# Altium schematic sheet 四路运放.SchDoc
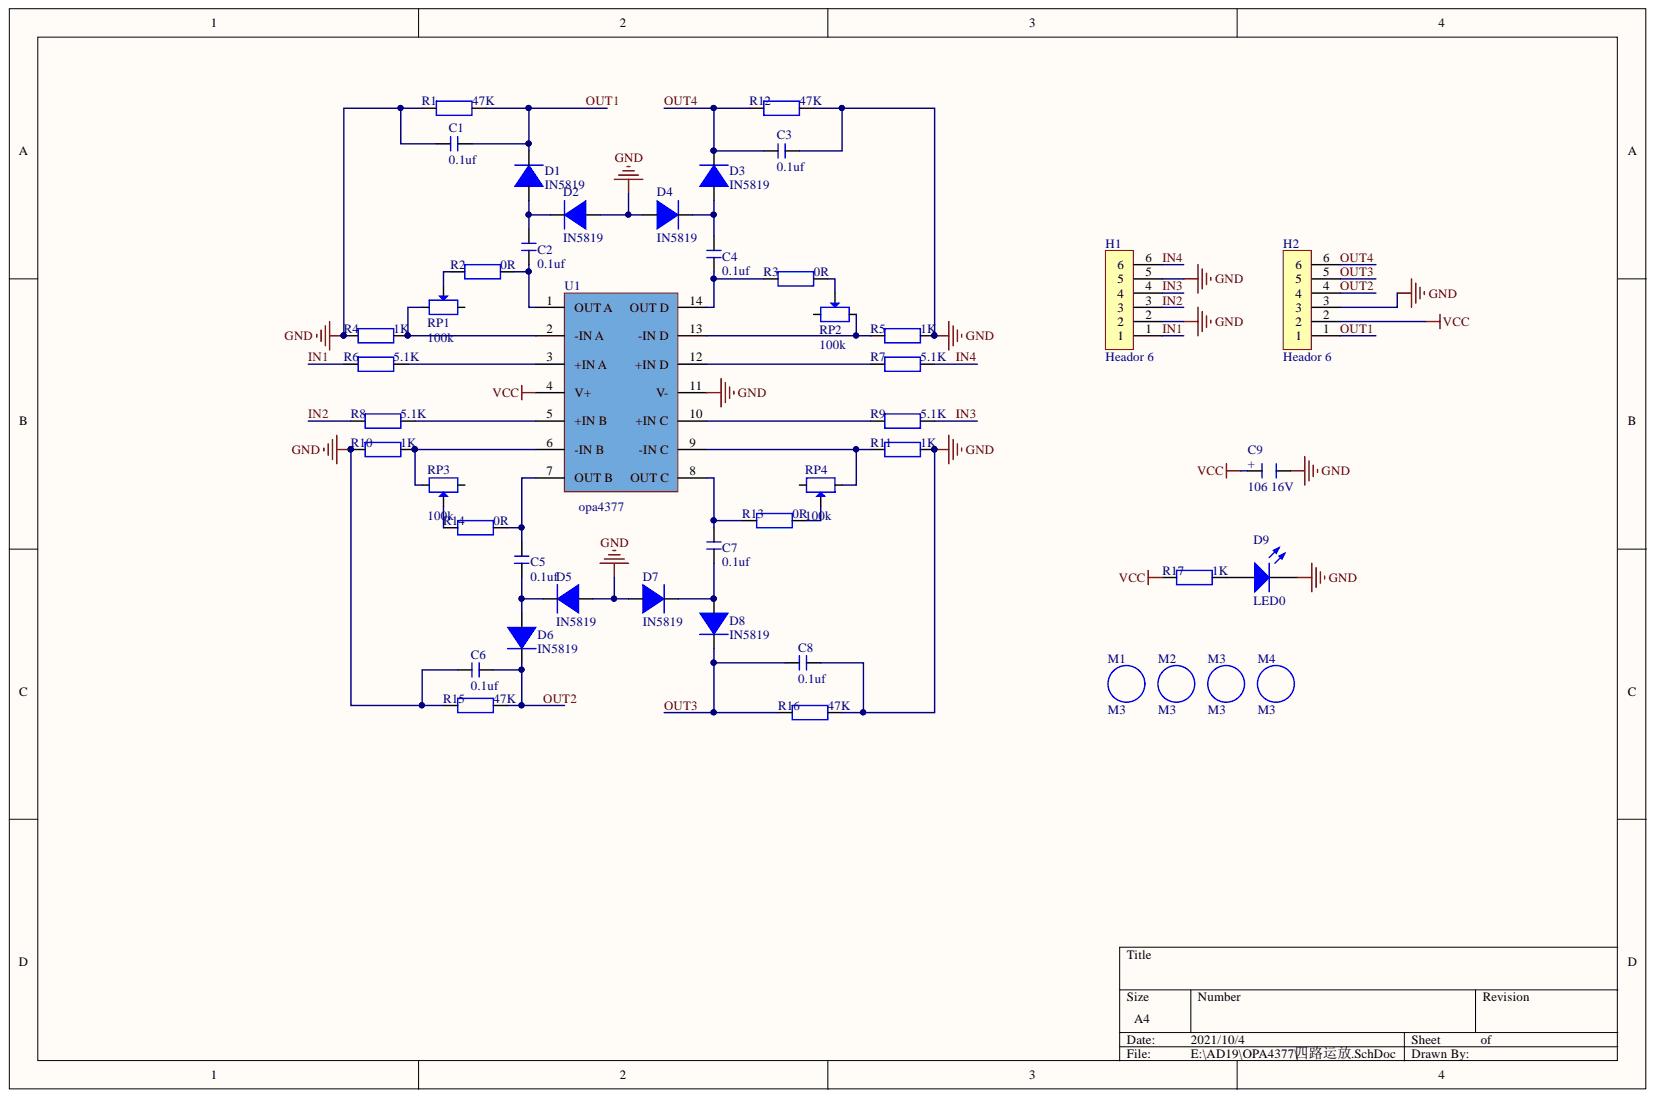
[diagram p0: 四路运放.SchDoc]

## Parts
C1  0.1uf  [0805C]
C2  0.1uf  [0805C]
C3  0.1uf  [0805C]
C4  0.1uf  [0805C]
C5  0.1uf  [0805C]
C6  0.1uf  [0805C]
C7  0.1uf  [0805C]
C8  0.1uf  [0805C]
C9  106 16V  [LQ_C1210T]
D1  IN5819  [DO214AC_SMA]
D2  IN5819  [DO214AC_SMA]
D3  IN5819  [DO214AC_SMA]
D4  IN5819  [DO214AC_SMA]
D5  IN5819  [DO214AC_SMA]
D6  IN5819  [DO214AC_SMA]
D7  IN5819  [DO214AC_SMA]
D8  IN5819  [DO214AC_SMA]
D9  LED0  [LED-0805]
H1  Heador 6  [LQ_SIP10N]
H2  Heador 6  [LQ_SIP10N]
M1  M3  [LQ_SCROW3&5MM]
M2  M3  [LQ_SCROW3&5MM]
M3  M3  [LQ_SCROW3&5MM]
M4  M3  [LQ_SCROW3&5MM]
R1  47K  [0805R]
R2  0R  [0805R]
R3  0R  [0805R]
R4  1K  [0805R]
R5  1K  [0805R]
R6  5.1K  [0805R]
R7  5.1K  [0805R]
R8  5.1K  [0805R]
R9  5.1K  [0805R]
R10  1K  [0805R]
R11  1K  [0805R]
R12  47K  [0805R]
R13  0R  [0805R]
R14  0R  [0805R]
R15  47K  [0805R]
R16  47K  [0805R]
R17  1K  [0805R]
RP1  100k  [VR3296W]
RP2  100k  [VR3296W]
RP3  100k  [VR3296W]
RP4  100k  [VR3296W]
U1  opa4377  [LQ_TSSOP14-OPA4377AIPW]

## Nets
GND: C1.1 C3.2 C6.1 C8.2 C9.2 D2.1 D4.1 D5.1 D7.1 D9.2 H1.2 H1.5 H2.3 R1.1 R4.1 R5.2 R10.1 R11.2 R12.2 R15.1 R16.2 U1.11(V-)
VCC: C9.1 H2.2 R17.1 U1.4(V+)
IN1: H1.1 R6.1
IN2: H1.3 R8.1
IN3: H1.4 R9.2
IN4: H1.6 R7.2
OUT1: C1.2 D1.2 H2.1 R1.2
OUT2: C6.2 D6.2 H2.4 R15.2
OUT3: C8.1 D8.2 H2.5 R16.1
OUT4: C3.1 D3.2 H2.6 R12.1
NetC2_1: C2.1 R2.2 U1.1(OUT_A)
NetC2_2: C2.2 D1.1 D2.2
NetC4_1: C4.1 R3.1 U1.14(OUT_D)
NetC4_2: C4.2 D3.1 D4.2
NetC5_1: C5.1 D5.2 D6.1
NetC5_2: C5.2 R14.2 U1.7(OUT_B)
NetC7_1: C7.1 D7.2 D8.1
NetC7_2: C7.2 R13.1 U1.8(OUT_C)
NetD9_1: D9.1 R17.2
NetR2_1: R2.1 RP1.2
NetR3_2: R3.2 RP2.2
NetR4_2: R4.2 RP1.1 U1.2(-IN_A)
NetR5_1: R5.1 RP2.3 U1.13(-IN_D)
NetR6_2: R6.2 U1.3(+IN_A)
NetR7_1: R7.1 U1.12(+IN_D)
NetR8_2: R8.2 U1.5(+IN_B)
NetR9_1: R9.1 U1.10(+IN_C)
NetR10_2: R10.2 RP3.3 U1.6(-IN_B)
NetR11_1: R11.1 RP4.1 U1.9(-IN_C)
NetR13_2: R13.2 RP4.2
NetR14_1: R14.1 RP3.2

Not connected: RP1 3; RP2 1; RP3 1; RP4 3

## Library data of the parts
C1-C8 = Cap: Component Kind: Standard; Library Name: 四路运放.SchLib
C9 = C+: Component Kind: Standard; Library Name: 四路运放.SchLib
D9 = LED0: Typical INFRARED GaAs LED; Component Kind: Standard; LatestRevisionDate: 08-Jul-2005; LatestRevisionNote: Mech Layer 1 removed.; Library Name: Miscellaneous Devices.IntLib; PackageDescription: LED; 2 Leads; PackageReference: LED-0; Published: 8-Jun-2000; Simulation: LED0; Publisher: Altium Limited
H1 H2 = Heador 6: 排针; Component Kind: Standard; Library Name: 四路运放.SchLib
R1-R17 = RES: Component Kind: Standard
RP1-RP4 = RP: 电位器; Component Kind: Standard; Library Name: 四路运放.SchLib
D1-D8 = IN5819: Component Kind: Standard; Library Name: 四路运放.SchLib
M1-M4 = M3: Component Kind: Standard; Library Name: 四路运放.SchLib
U1 = opa4377: Component Kind: Standard; Library Name: 四路运放.SchLib
